# Altium schematic sheet sensors.SchDoc
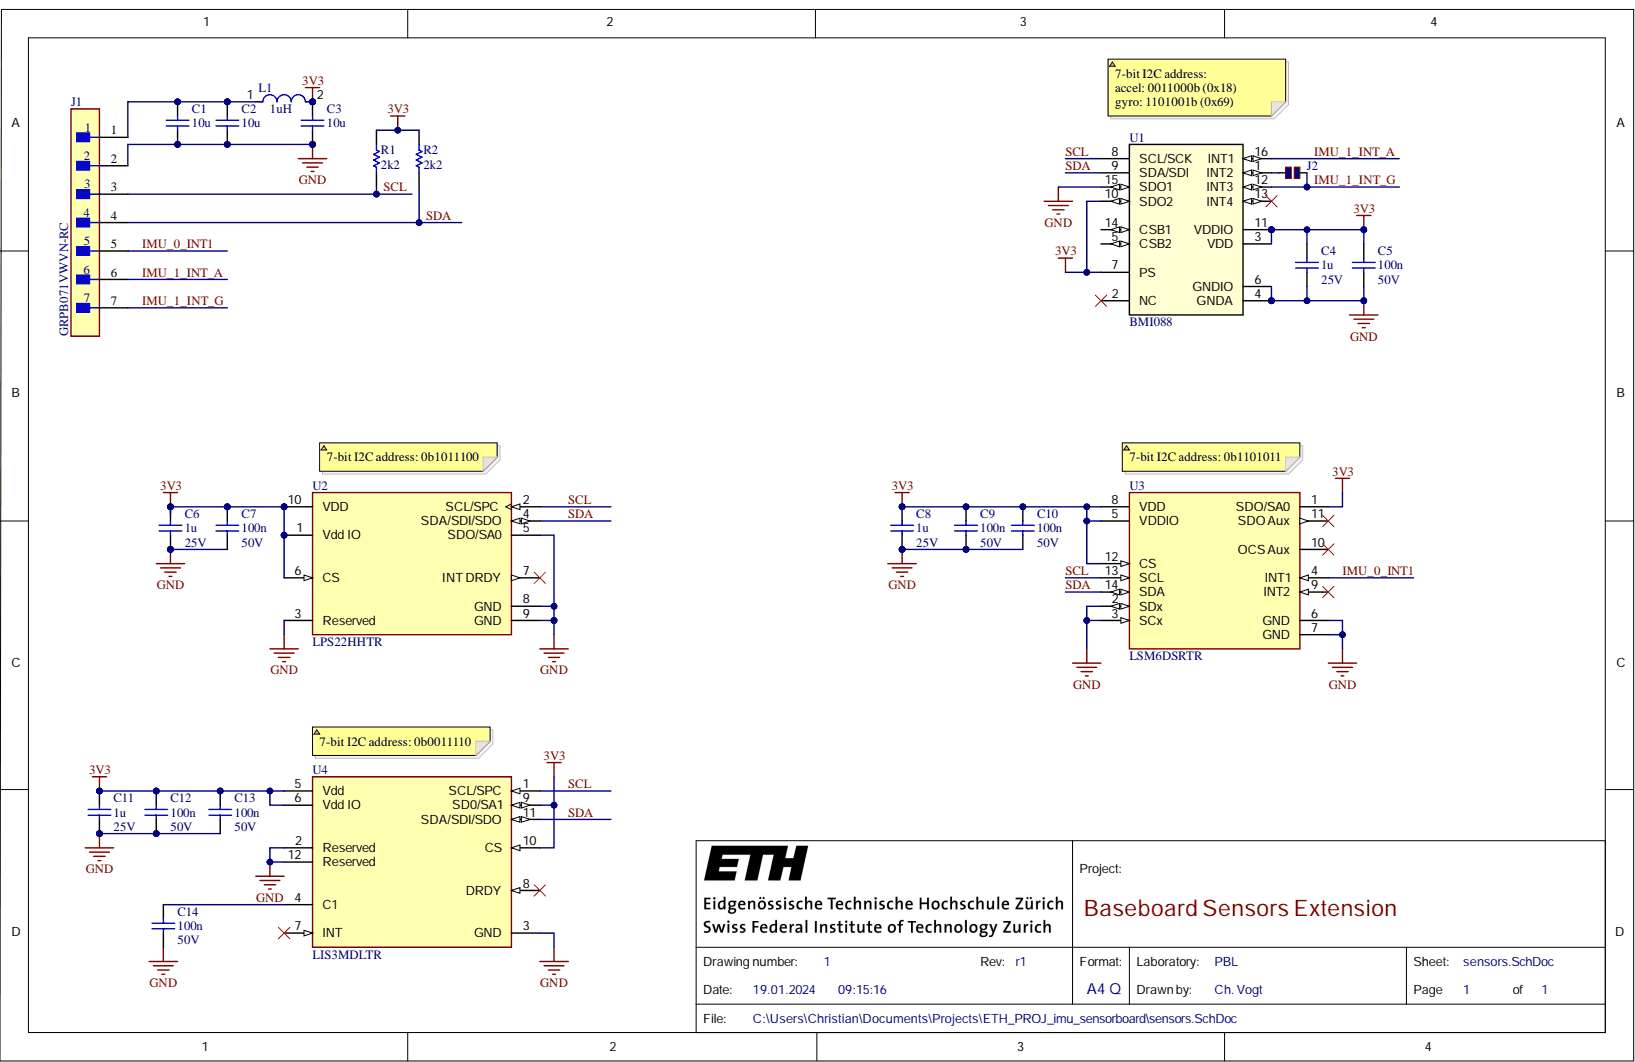
[diagram p0: sensors.SchDoc]

## Parts
C1  10u  [CAPC0402]
C2  10u  [CAPC0402]
C3  10u  [CAPC0402]
C4  1u  Rated DC Voltage (URdc): 25V  [CAPC0402]
C5  100n  Rated DC Voltage (URdc): 50V  [CAPC0402]
C6  1u  Rated DC Voltage (URdc): 25V  [CAPC0402]
C7  100n  Rated DC Voltage (URdc): 50V  [CAPC0402]
C8  1u  Rated DC Voltage (URdc): 25V  [CAPC0402]
C9  100n  Rated DC Voltage (URdc): 50V  [CAPC0402]
C10  100n  Rated DC Voltage (URdc): 50V  [CAPC0402]
C11  1u  Rated DC Voltage (URdc): 25V  [CAPC0402]
C12  100n  Rated DC Voltage (URdc): 50V  [CAPC0402]
C13  100n  Rated DC Voltage (URdc): 50V  [CAPC0402]
C14  100n  Rated DC Voltage (URdc): 50V  [CAPC0402]
J1  GRPB071VWVN-RC  [FP-GRPB071VWVN-RC-MFG]
J2  Solder Jumper  [Small Solder Jumper]  (value not drawn)
L1  Inductance: 1uH  [FP-MLZ1005-MFG]  (hidden comment: MLZ1005M1R0WT000)
R1  2k2  [RES0402]
R2  2k2  [RES0402]
U1  BMI088  [QFN_BMI085_BOS]
U2  LPS22HHTR  [FP-HLGA-10L-DM00386636-MFG]
U3  LSM6DSRTR  [FP-LGA-14L-DM00249496-MFG]
U4  LIS3MDLTR  [FP-VFLGA-12-8365767-MFG]

## Nets
3V3: C3.2 C4.2 C5.2 C6.2 C7.2 C8.2 C9.2 C10.2 C11.2 C12.2 C13.2 L1.2 R1.2 R2.2 U1.3(VDD) U1.7(PS) U1.10(SDO2) U1.11(VDDIO) U2.1(Vdd_IO) U2.6(CS) U2.10(VDD) U3.1(SDO/SA0) U3.5(VDDIO) U3.8(VDD) U3.12(CS) U4.5(Vdd) U4.6(Vdd_IO) U4.9(SD0/SA1) U4.10(CS)
GND: C1.1 C2.1 C3.1 C4.1 C5.1 C6.1 C7.1 C8.1 C9.1 C10.1 C11.1 C12.1 C13.1 C14.1 J1.2 U1.4(GNDA) U1.6(GNDIO) U1.15(SDO1) U2.3(Reserved) U2.5(SDO/SA0) U2.8(GND) U2.9(GND) U3.2(SDx) U3.3(SCx) U3.6(GND) U3.7(GND) U4.2(Reserved) U4.3(GND) U4.12(Reserved)
IMU_0_INT1: J1.5 U3.4(INT1)
IMU_1_INT_A: J1.6 U1.16(INT1)
IMU_1_INT_G: J1.7 J2.1 U1.12(INT3)
SCL: J1.3 R1.1 U1.8(SCL/SCK) U2.2(SCL/SPC) U3.13(SCL) U4.1(SCL/SPC)
SDA: J1.4 R2.1 U1.9(SDA/SDI) U2.4(SDA/SDI/SDO) U3.14(SDA) U4.11(SDA/SDI/SDO)
NetC1_2: C1.2 C2.2 J1.1 L1.1
NetC14_2: C14.2 U4.4(C1)
NetJ2_2: J2.2 U1.1(INT2)

Not connected: U1 2(NC) 5(CSB2) 13(INT4) 14(CSB1); U2 7(INT_DRDY); U3 9(INT2) 10(OCS_Aux) 11(SDO_Aux); U4 7(INT) 8(DRDY)

## Library data of the parts
C1-C3 = cap0402: Ceramic Capacitor, Multilayer, Ceramic, 10V, 20% +Tol, 20% -Tol, X5R, 15% TC, 10uF, Surface Mount, 0402; Manufacturer: Samsung; Manufacturer Part Number: CL05A106MP5NUNC; Multilayer: Yes; Capacitor Type: Ceramic; Case/Package: 0402; Category: Ceramic Capacitors; Component Kind: Standard; ComponentLink1Description: https://datasheet.ciiva.com:443/pdfs/VipMasterIC/IC/SEMS/SEMS-S-A0008384515/SEMS-S-A0008872829-1.pdf?src-supplier=IHS+Markit; ComponentLink1URL: https://datasheet.ciiva.com:443/pdfs/VipMasterIC/IC/SEMS/SEMS-S-A0008384515/SEMS-S-A0008872829-1.pdf?src-supplier=IHS+Markit; Dielectric Material: Ceramic; Height: 0.7mm; JESD-609 Code: e3; Length: 1mm; Max Operating Temperature: 85°C; Min Operating Temperature: -55°C; Mounting Technology: Surface Mount; Package Shape: Rectangular; Package Style: SMTMeter; Packaging: Tape and Reel; Pins: 2; Pricing: 1=0.18, 10=0.115, 50=0.0726, 100=0.058, 500=0.04192, 1000=0.03708, 2500=0.03546, 5000=0.03224 (USD); Rated DC Voltage (URdc): 10V; Reach SVHC Compliant: Yes; RoHS: Yes; RoHS Compliant: Yes; Stock: 2505826; Supplier: Digikey; Supplier Part Number: 1276-1450-1-ND; Temperature Characteristics Code: X5R; Terminal Finish: Nickel; Termination: Wraparound; Tolerance  (fill in as +-): 20%; Tolerance (fill in as +-): 20%; Value: 10uF; Width: 0.5mm; Library Name: k26_FPGA_drone_baseboard.SCHLIB
C4 C6 C8 C11 = cap0402: Ceramic Capacitor, Multilayer, Ceramic, 25V, 20% +Tol, 20% -Tol, X5R, 15% TC, 1uF, Surface Mount, 0402; Manufacturer: Taiyo Yuden; Manufacturer Part Number: TMK105BJ105MV-F; Multilayer: Yes; Capacitor Type: Ceramic; Case/Package: 0402; Category: Ceramic Capacitors; Component Kind: Standard; ComponentLink1Description: https://datasheet.ciiva.com:443/pdfs/VipMasterIC/IC/TAIY/TAIY-S-A0009103435/TAIY-S-A0009102669-1.pdf?src-supplier=IHS+Markit; ComponentLink1URL: https://datasheet.ciiva.com:443/pdfs/VipMasterIC/IC/TAIY/TAIY-S-A0009103435/TAIY-S-A0009102669-1.pdf?src-supplier=IHS+Markit; ComponentLink2Description: https://datasheet.ciiva.com/11596/tmk105bj105mv-f-ss-11596466.pdf?src-supplier=Avnet; ComponentLink2URL: https://datasheet.ciiva.com/11596/tmk105bj105mv-f-ss-11596466.pdf?src-supplier=Avnet; ComponentLink3Description: https://datasheet.ciiva.com/30154/128tmk105bj105mv-f-30154639.pdf?src-supplier=Onlinecomponents.com; ComponentLink3URL: https://datasheet.ciiva.com/30154/128tmk105bj105mv-f-30154639.pdf?src-supplier=Onlinecomponents.com; ComponentLink4Description: https://datasheet.ciiva.com/15922/tmk105bj105mv-f-pdf-15922317.pdf?src-supplier=Onlinecomponents.com; ComponentLink4URL: https://datasheet.ciiva.com/15922/tmk105bj105mv-f-pdf-15922317.pdf?src-supplier=Onlinecomponents.com; ComponentLink5Description: https://datasheet.ciiva.com/13404/mlcc-all-e-541559-13404206.pdf?src-supplier=Mouser; ComponentLink5URL: https://datasheet.ciiva.com/13404/mlcc-all-e-541559-13404206.pdf?src-supplier=Mouser; Dielectric Material: Ceramic; Height: 0.5mm; JESD-609 Code: e3; Length: 1mm; Max Operating Temperature: 85°C; Min Operating Temperature: -55°C; Mounting Technology: Surface Mount; Package Shape: Rectangular; Package Style: SMTMeter; Packaging: Tape and Reel; Pins: 2; Pricing: 200=0.05600, 600=0.05000, 1000=0.03900 (CHF); Reach SVHC Compliant: Yes; RoHS: Yes; RoHS Compliant: Yes; Stock: 2400; Supplier: Rs; Supplier Part Number: 1843748; Temperature Characteristics Code: X5R; Terminal Finish: Nickel; Termination: Wraparound; Tolerance  (fill in as +-): 20%; Tolerance (fill in as +-): 20%; Value: 1uF; Width: 0.5mm; Library Name: k26_FPGA_drone_baseboard.SCHLIB
C5 C7 C9 C10 C12-C14 = cap0402: Ceramic Capacitor, Multilayer, Ceramic, 50V, 10% +Tol, 10% -Tol, X5R, 15% TC, 0.1uF, Surface Mount, 0402; Manufacturer: Yageo; Manufacturer Part Number: CC0402KRX5R9BB104; Capacitance: 100nF; Multilayer: Yes; Capacitor Type: Ceramic; Category: Ceramic Capacitors; Component Kind: Standard; ComponentLink1Description: https://datasheet.ciiva.com:443/pdfs/VipMasterIC/IC/YAGO/YAGO-S-A0006851646/YAGO-S-A0006851646-1.pdf?src-supplier=IHS+Markit; ComponentLink1URL: https://datasheet.ciiva.com:443/pdfs/VipMasterIC/IC/YAGO/YAGO-S-A0006851646/YAGO-S-A0006851646-1.pdf?src-supplier=IHS+Markit; ComponentLink2Description: http://www.yageo.com/documents/recent/UPY-GPHC_X5R_4V-to-50V_25.pdf?src-supplier=Digi-Key; ComponentLink2URL: http://www.yageo.com/documents/recent/UPY-GPHC_X5R_4V-to-50V_25.pdf?src-supplier=Digi-Key; ComponentLink3Description: http://www.yageo.com/documents/recent/UPY-GPHC_X5R_4V-to-50V_23.pdf?src-supplier=Digi-Key; ComponentLink3URL: http://www.yageo.com/documents/recent/UPY-GPHC_X5R_4V-to-50V_23.pdf?src-supplier=Digi-Key; Dielectric Material: Ceramic; Height: 0.5mm; JESD-609 Code: e3; Length: 1mm; Max Operating Temperature: 85°C; Min Operating Temperature: -55°C; Mount: Surface Mount; Negative Tolerance: 10%; Number of Pins: 2; Package Shape: Rectangular; Package Style: SMTMeter; Packaging: Tape and Reel; Positive Tolerance: 10%; Pricing 1: 10000=0.00890, 50000=0.00870 (CHF); Reach SVHC Compliant: Yes; RoHS: Yes; RoHS Compliant: Yes; Size Code: 0402; Stock 1: 180000; Supplier 1: Farnell; Supplier Part Number 1: 3366442; Temperature Characteristics Code: X5R; Terminal Finish: Nickel; Termination: Wraparound; Width: 0.5mm; Library Name: k26_FPGA_drone_baseboard.SCHLIB
J1 = CMP-25680-000036-1: CONN HEADER VERT 7POS 1.27MM; Connector Type: Male Pin; Number of Positions: 7; Pitch: 0.05inch; Component Kind: Standard; Contact Mating Length: 0.118inch; Contact Plating: Gold; Contact Type: Male Pin; Lead Free: Lead Free; Library Name: Altium Content Vault; Mount: Through Hole; Number of Rows: 1; Package Quantity: 1; Packaging: Bulk; RoHS Compliant: Yes; Termination: Solder
J2 = CMP-00005-5: Value: 1; Component Kind: Standard; Library Name: ETHZ Vault; Simulation: SIM-001-0001-1; Technology: SMT
L1 = CMP-08253-000219-1: Shielded Multilayer Inductor 1uH ±20% 450mA 455mΩ SMD 0402; Core Material: Ferrite; DC Resistance (DCR): 455mR; Saturation Current: 100mA; Self Resonant Frequency: 170MHz; Test Frequency: 2MHz; Case Code (Imperial): 0402; Case Code (Metric): 1005; Case/Package: 0402; Component Kind: Standard; Current Rating: 450mA; Height - Seated (Max): 0.022inch; Length: 0.039inch; Library Name: Altium Content Vault; Max Operating Temperature: 125°C; Min Operating Temperature: -55°C; Mount: Surface Mount; Package Quantity: 10000; Packaging: Tape and Reel; RoHS Compliant: Yes; Shielding: Shielded; Tolerance: 20%; Width: 0.02inch
R1 R2 = res0402: Fixed Resistor, Metal Glaze/thick Film, 0.063W, 2200ohm, 50V, 1% +/-Tol, 100ppm/Cel, Surface Mount, 0402; Manufacturer: Vishay; Manufacturer Part Number: CRCW04022K20FKED; Package Height: 0.35mm; Package Length: 1mm; Package Width: 0.5mm; Power: 63mW; Rated Temperature: 70°C; Reference Standard: AEC-Q200; Temperature Coefficient: 100ppm/°C; Value: 2.2kR; Case/Package: 0402; Category: Chip SMD Resistors; Component Kind: Standard; ComponentLink1Description: https://datasheet.ciiva.com:443/pdfs/VipMasterIC/IC/VISH/VISH-S-A0007266705/VISH-S-A0007266705-1.pdf?src-supplier=IHS+Markit; ComponentLink1URL: https://datasheet.ciiva.com:443/pdfs/VipMasterIC/IC/VISH/VISH-S-A0007266705/VISH-S-A0007266705-1.pdf?src-supplier=IHS+Markit; ComponentLink2Description: https://datasheet.ciiva.com/11811/0900766b80d98824-11811709.pdf?src-supplier=Rs; ComponentLink2URL: https://datasheet.ciiva.com/11811/0900766b80d98824-11811709.pdf?src-supplier=Rs; ComponentLink3Description: https://datasheet.ciiva.com/3256/dcrcwe3-3256341.pdf?src-supplier=Avnet; ComponentLink3URL: https://datasheet.ciiva.com/3256/dcrcwe3-3256341.pdf?src-supplier=Avnet; ComponentLink4Description: https://datasheet.ciiva.com/16209/dcrcwe3-16209157.pdf?src-supplier=Future+Electronics; ComponentLink4URL: https://datasheet.ciiva.com/16209/dcrcwe3-16209157.pdf?src-supplier=Future+Electronics; ComponentLink5Description: https://datasheet.ciiva.com/2464/dcrcwe3-2464486.pdf?src-supplier=Digi-Key; ComponentLink5URL: https://datasheet.ciiva.com/2464/dcrcwe3-2464486.pdf?src-supplier=Digi-Key; ComponentLink6Description: https://datasheet.ciiva.com/2464/dcrcwe3-2464487.pdf?src-supplier=Digi-Key; ComponentLink6URL: https://datasheet.ciiva.com/2464/dcrcwe3-2464487.pdf?src-supplier=Digi-Key; ComponentLink7Description: https://datasheet.ciiva.com/2464/dcrcwe3-2464488.pdf?src-supplier=Digi-Key; ComponentLink7URL: https://datasheet.ciiva.com/2464/dcrcwe3-2464488.pdf?src-supplier=Digi-Key; ComponentLink8Description: https://datasheet.ciiva.com/5541/dcrcwe3-109170-5541416.pdf?src-supplier=Mouser; ComponentLink8URL: https://datasheet.ciiva.com/5541/dcrcwe3-109170-5541416.pdf?src-supplier=Mouser; ComponentLink9Description: https://datasheet.ciiva.com/26316/fld-crcw-e3-data-en-26316650.pdf?src-supplier=Distrelec; ComponentLink9URL: https://datasheet.ciiva.com/26316/fld-crcw-e3-data-en-26316650.pdf?src-supplier=Distrelec; ComponentLink10Description: https://datasheet.ciiva.com/7777/1697337-7777227.pdf?src-supplier=Element14; ComponentLink10URL: https://datasheet.ciiva.com/7777/1697337-7777227.pdf?src-supplier=Element14; ComponentLink11Description: https://datasheet.ciiva.com/7777/1697337-7777228.pdf?src-supplier=Element14; ComponentLink11URL: https://datasheet.ciiva.com/7777/1697337-7777228.pdf?src-supplier=Element14; ComponentLink12Description: https://datasheet.ciiva.com/16778/70201119-16778943.pdf?src-supplier=Allied+Electronics; ComponentLink12URL: https://datasheet.ciiva.com/16778/70201119-16778943.pdf?src-supplier=Allied+Electronics; Construction: Chip; JESD-609 Code: e3; Max Operating Temperature: 155°C; Min Operating Temperature: -55°C; Mounting Technology: Surface Mount; Package Shape: Rectangular; Package Style: SMTMeter; Packaging: Tape and Reel; Pins: 2; Pricing: 10000=0.00400, 50000=0.00390, 100000=0.00380 (CHF); Reach SVHC Compliant: Yes; Resistor Type: FIXED RESISTOR; RoHS: Yes; RoHS Compliant: Yes; Stock: 30000; Supplier: Farnell; Supplier Part Number: 2121643; Technology: METAL GLAZE/THICK FILM; Terminal Finish: Nickel; Termination: Wraparound; Tolerance: 1%; Working Voltage: 50V; Library Name: k26_FPGA_drone_baseboard.SCHLIB
U1 = BMI088: No Description Available; Mfr_Name: Bosch Sensortec; Component Kind: Standard; Manufacturer_Part_Number: BMI088; RefDes: RefDes; Type: DEV; Copyright: Copyright (C) 2024 Ultra Librarian. All rights reserved.; Library Name: k26_FPGA_drone_baseboard.SCHLIB
U2 = CMP-12182-000001-1: CONSUMER MEMS; Manufacturer: STMicroelectronics; Max Supply Voltage: 3.7V; Min Supply Voltage: 1.7V; Operating Supply Current: 4-12uA; Port Style: No Port; Sensor Output: I2C,SPI; Automotive: No; Category: IC; Component Kind: Standard; ComponentLink2Description: Datasheet; ComponentLink2URL: https://www.st.com/resource/en/datasheet/lps22hh.pdf; Device Class L1: Sensors; Device Class L2: Pressure Sensors; Device Class L3: unset; Digikey Description: CONSUMER MEMS; Footprint Url: https://www.st.com/content/ccc/resource/technical/document/technical_note/73/17/9c/16/dd/96/4a/01/CD00134799.pdf/files/CD00134799.pdf/jcr:content/translations/en.CD00134799.pdf; Height: 0.8mm; Lead Free: Yes; Manufacturer Part Number: LPS22HHTR; Octopart: https://octopart.com/lps22hhtr-stmicroelectronics-94834025; Package: HLGA-10L-DM00386636; RoHS: TRUE; Temperature: -40°C to +85°C; Temperature Range High: +85°C; Temperature Range Low: -40°C; Library Name: k26_FPGA_drone_baseboard.SCHLIB
U3 = CMP-12177-000003-1: INEMO INERTIAL MODULE: 3D ACCELE; Manufacturer: STMicroelectronics; Accel Range: 2/4/8/16g; Gyro Range: 125/250/500/1000/2000/4000°/s; Max Supply Voltage: 3.6V; Min Supply Voltage: 1.62V; Operating Supply Current: 360-1200uA; Sensor Output: I2C,SPI; Sensor Type: Temperature,Accelerometer,Gyroscope; Automotive: No; Category: IC; Component Kind: Standard; ComponentLink2Description: Datasheet; ComponentLink2URL: https://www.st.com/resource/en/datasheet/lsm6dsr.pdf; Device Class L1: Sensors; Device Class L2: Motion Sensors; Device Class L3: Inertial Measurement Units (IMUs); Digikey Description: INEMO INERTIAL MODULE: 3D ACCELE; Footprint Url: https://www.st.com/content/ccc/resource/technical/document/technical_note/73/17/9c/16/dd/96/4a/01/CD00134799.pdf/files/CD00134799.pdf/jcr:content/translations/en.CD00134799.pdf; Height: 0.86mm; Lead Free: Yes; Manufacturer Part Number: LSM6DSRTR; Mouser Description: In a Pack of 2, LSM6DSRTR STMicroelectronics, 3-Axis Accelerometer, Gyroscope, 14-Pin LGA; Octopart: https://octopart.com/lsm6dsrtr-stmicroelectronics-93180177; Package: LGA-14L-DM00249496; RoHS: TRUE; Temperature: -40°C to +85°C; Temperature Range High: +85°C; Temperature Range Low: -40°C; Library Name: k26_FPGA_drone_baseboard.SCHLIB
U4 = CMP-2000-07463-2: SENSOR MR I2C/SPI 12LGA; Manufacturer: STMicroelectronics; Max Supply Voltage: 3.6V; Min Supply Voltage: 1.71V; Operating Supply Current: 40-270uA; Sensing Method: Magnetic; Sensor Output: I2C,SPI; Automotive: No; Category: IC; Component Kind: Standard; ComponentLink2Description: Datasheet; ComponentLink2URL: https://www.st.com/resource/en/datasheet/lis3mdl.pdf; Device Class L1: Sensors; Device Class L2: Magnetic Sensors; Device Class L3: unset; Digikey Description: SENSOR MR I2C/SPI 12LGA; Footprint Url: https://www.st.com/content/ccc/resource/technical/document/technical_note/73/17/9c/16/dd/96/4a/01/CD00134799.pdf/files/CD00134799.pdf/jcr:content/translations/en.CD00134799.pdf; Height: 1mm; Lead Free: Yes; Manufacturer Part Number: LIS3MDLTR; Mouser Description: Board Mount Hall Effect / Magnetic Sensors Ultra Lo-Pwr Hi Perf 3-Axis 1.9-3.6V; Octopart: https://octopart.com/lis3mdltr-stmicroelectronics-29403125; Package: VFLGA-12-8365767; RoHS: TRUE; Temperature: -40°C to +85°C; Temperature Range High: +85°C; Temperature Range Low: -40°C; Library Name: k26_FPGA_drone_baseboard.SCHLIB
